# Altium schematic sheet 13_MRAM_RTC_WDT.SchDoc(13_MRAM_RTC_WDT)
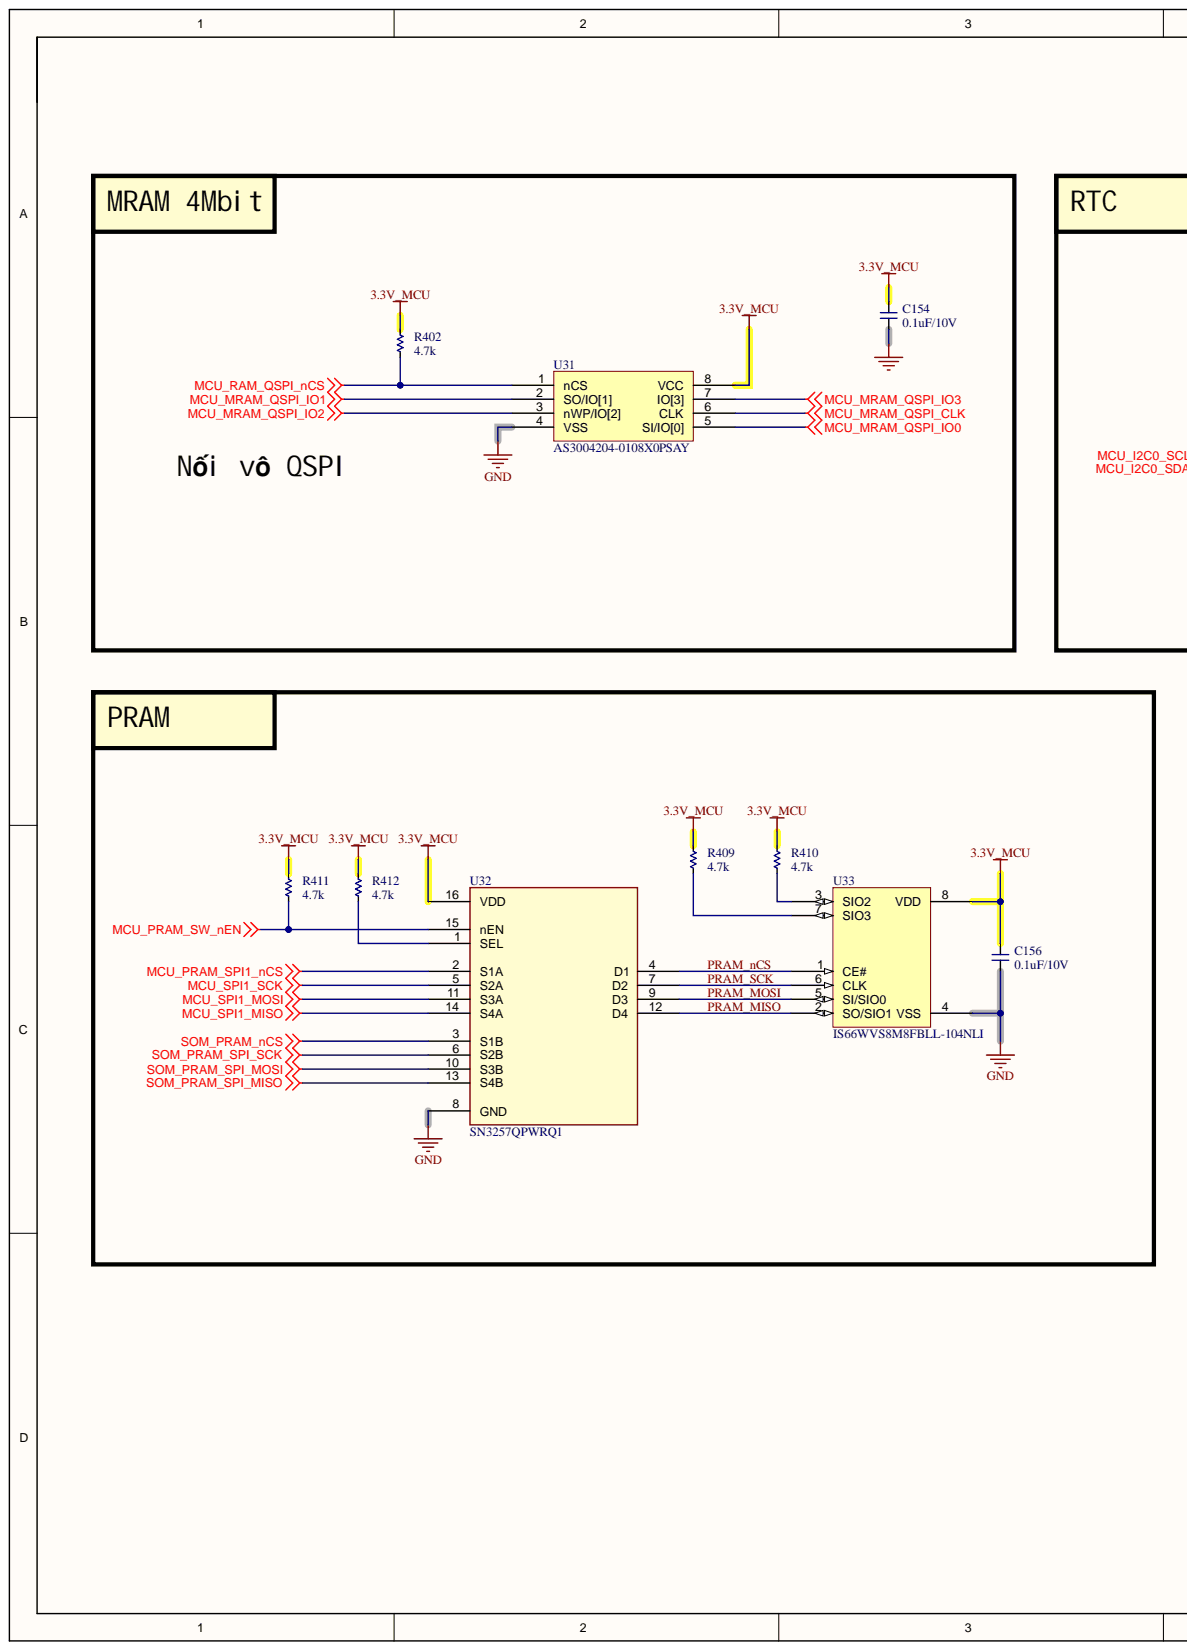
[diagram p0: 13_MRAM_RTC_WDT.SchDoc, left]
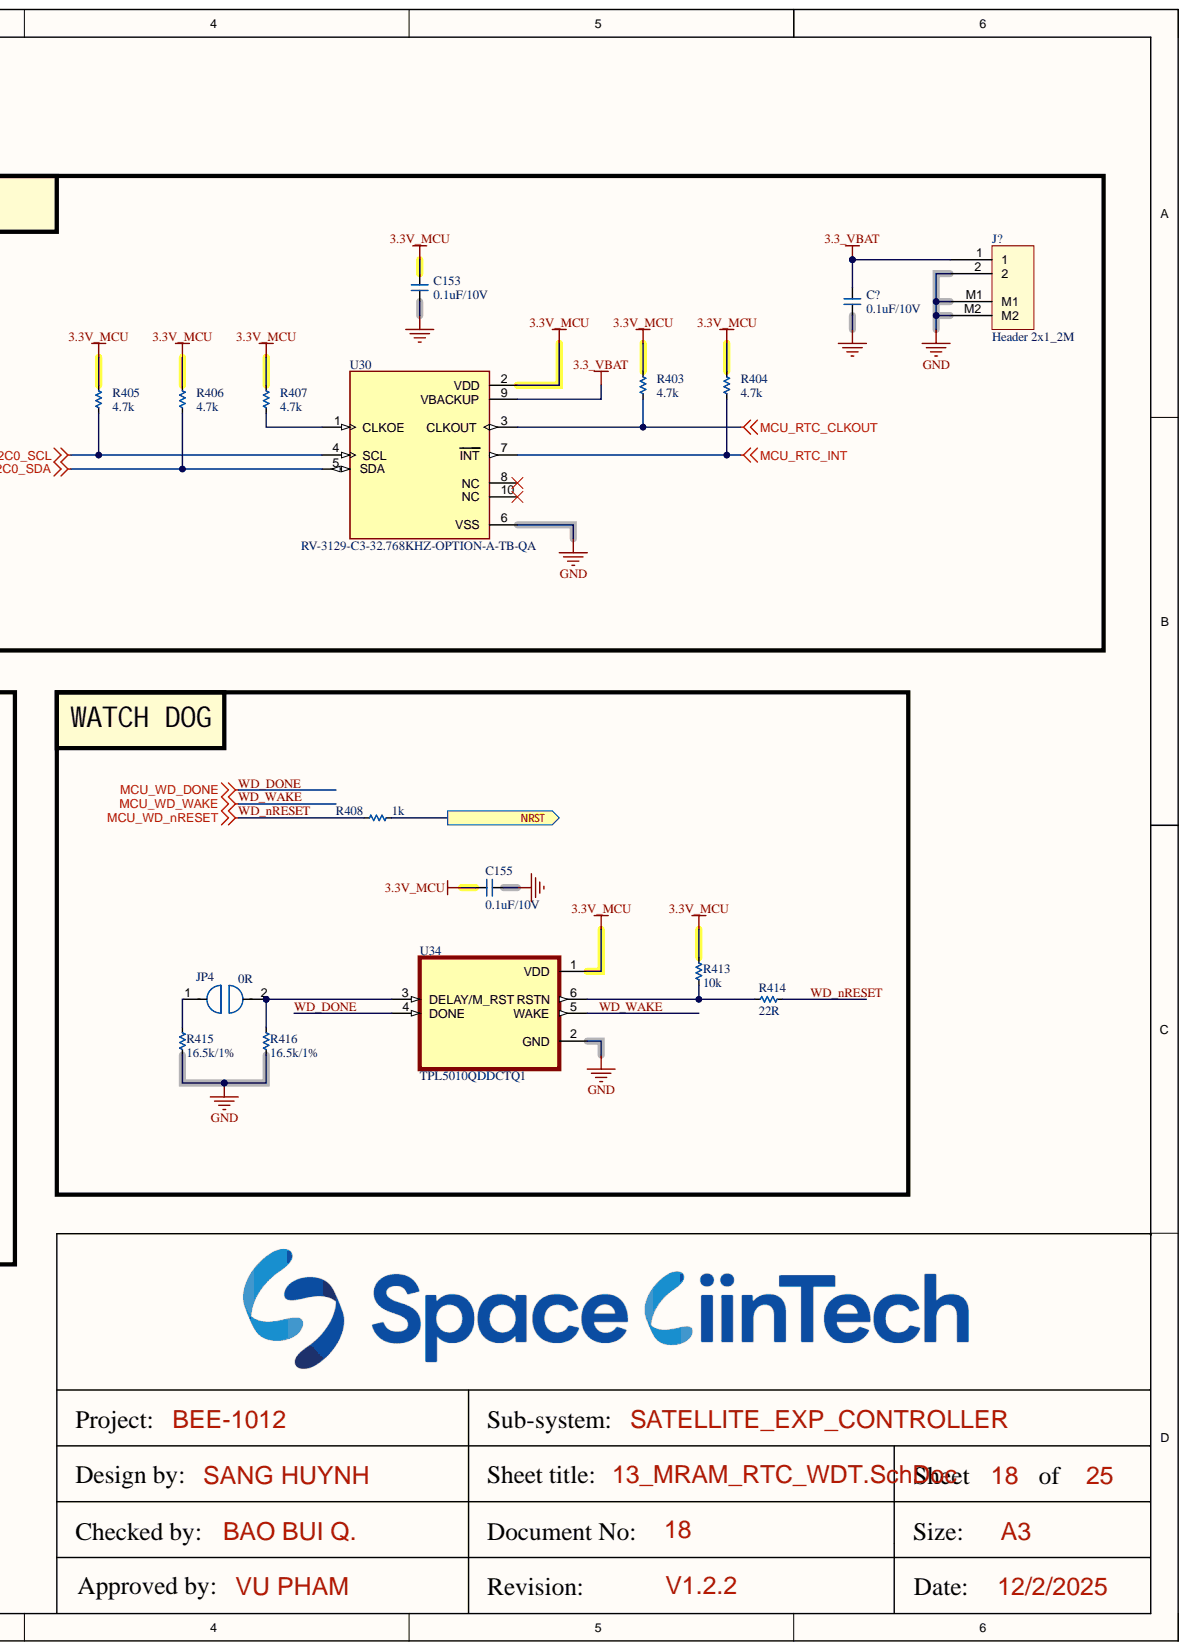
[diagram p1: 13_MRAM_RTC_WDT.SchDoc, right]

## Parts
C153  0.1uF/10V  [CAP_C_1005X60]
C154  0.1uF/10V  [CAP_C_1005X60]
C155  0.1uF/10V  [CAP_C_1005X60]
C156  0.1uF/10V  [CAP_C_1005X60]
C?  0.1uF/10V  [CAP_C_1005X60]
J?  Header 2x1_2M  [MOLEX_0533980271]
JP4  0R  [RES_C_1608X60]
R402  4.7k  [RES_C_1005X40]
R403  4.7k  [RES_C_1005X40]
R404  4.7k  [RES_C_1005X40]
R405  4.7k  [RES_C_1005X40]
R406  4.7k  [RES_C_1005X40]
R407  4.7k  [RES_C_1005X40]
R408  1k  [RES_C_1005X40]
R409  4.7k  [RES_C_1005X40]
R410  4.7k  [RES_C_1005X40]
R411  4.7k  [RES_C_1005X40]
R412  4.7k  [RES_C_1005X40]
R413  10k  [RES_C_1005X40]
R414  22R  [RES_C_1005X40]
R415  16.5k/1%  [RES_C_1005X40]
R416  16.5k/1%  [RES_C_1005X40]
U30  RV-3129-C3-32.768KHZ-OPTION-A-TB-QA  [IC_RV-3129-C3_32.768KHZ_OPTION_A_TB_QA]
U31  AS3004204-0108X0PSAY  [SOIC127P790X216-8]
U32  SN3257QPWRQ1  [SOIC_PRQ1_TEX]
U33  IS66WVS8M8FBLL-104NLI  [SOIC8_150MIL_N_ISI]
U34  TPL5010QDDCTQ1  [SOT95P280X145-6N]

## Ports
NRST

## Nets
3.3_VBAT: C?.2 J?.1 U30.9(VBACKUP)
3.3V_MCU: C153.2 C154.2 C155.2 C156.1 R402.2 R403.2 R404.2 R405.2 R406.2 R407.1 R409.2 R410.2 R411.2 R412.2 R413.2 U30.2(VDD) U31.8(VCC) U32.16(VDD) U33.8(VDD) U34.1(VDD)
GND: C153.1 C154.1 C155.1 C156.2 C?.1 J?.2 J?.M1 J?.M2 R415.1 R416.1 U30.6(VSS) U31.4(VSS) U32.8(GND) U33.4(VSS) U34.2(GND)
MCU_I2C0_SCL: R405.1 U30.4(SCL)
MCU_I2C0_SDA: R406.1 U30.5(SDA)
MCU_MRAM_QSPI_CLK: U31.6(CLK)
MCU_MRAM_QSPI_IO0: U31.5(SI/IO[0])
MCU_MRAM_QSPI_IO1: U31.2(SO/IO[1])
MCU_MRAM_QSPI_IO2: U31.3(nWP/IO[2])
MCU_MRAM_QSPI_IO3: U31.7(IO[3])
MCU_PRAM_SPI1_nCS: U32.2(S1A)
MCU_PRAM_SW_nEN: R411.1 U32.15(nEN)
MCU_RAM_QSPI_nCS: R402.1 U31.1(nCS)
MCU_RTC_CLKOUT: R403.1 U30.3(CLKOUT)
MCU_RTC_INT: R404.1 U30.7(INT)
MCU_SPI1_MISO: U32.14(S4A)
MCU_SPI1_MOSI: U32.11(S3A)
MCU_SPI1_SCK: U32.5(S2A)
SOM_PRAM_nCS: U32.3(S1B)
SOM_PRAM_SPI_MISO: U32.13(S4B)
SOM_PRAM_SPI_MOSI: U32.10(S3B)
SOM_PRAM_SPI_SCK: U32.6(S2B)
NRST: R408.1 ; port NRST
PRAM_MISO: U32.12(D4) U33.2(SO/SIO1)
PRAM_MOSI: U32.9(D3) U33.5(SI/SIO0)
PRAM_nCS: U32.4(D1) U33.1(CE#)
PRAM_SCK: U32.7(D2) U33.6(CLK)
WD_DONE: U34.4(DONE)
WD_nRESET: R408.2 R414.1
WD_WAKE: U34.5(WAKE)
NetJP4_1: JP4.1 R415.2
NetJP4_2: JP4.2 R416.2 U34.3(DELAY/M_RST)
NetR407_2: R407.2 U30.1(CLKOE)
NetR409_1: R409.1 U33.7(SIO3)
NetR410_1: R410.1 U33.3(SIO2)
NetR412_1: R412.1 U32.1(SEL)
NetR413_1: R413.1 R414.2 U34.6(RSTN)

Not connected: U30 8(NC) 10(NC)

## Library data of the parts
C153-C156 C? = _CAP_C_2: CAP CHIP; Component Kind: Standard; Library Name: LABLIB.SchLib
JP4 = JUMPER: Component Kind: Standard; Library Name: EXP_V1.SchLib
R402-R416 = _RES_B_2: RES; Component Kind: Standard; Library Name: LABLIB.SchLib
U30 = RV-3129-C3_32.768KHZ_OPTION_A_TB_QA: Real-Time Clock Module, Temperature compensated, in 2.5 x 3.7 mm package; MF: Micro Crystal; MP: RV-3129-C3 32.768KHZ OPTION A TB QA; Check_prices: https://www.snapeda.com/parts/RV-3129-C3%2032.768KHZ%20OPTION%20A%20TB%20QA/Micro+Crystal/view-part/?ref=eda; Component Kind: Standard; Library Name: RV-3129-C3_32.768KHZ_OPTION_A_TB_QA.IntLib; Package: QFN-10 Micro Crystal; SnapEDA_Link: https://www.snapeda.com/parts/RV-3129-C3%2032.768KHZ%20OPTION%20A%20TB%20QA/Micro+Crystal/view-part/?ref=snap
U31 = IC_RAM_AS3004204-0108X0PSAY: Component Kind: Standard; Library Name: LABLIB.SchLib
U32 = IC_SW_SPI_SN3257QPWRQ1: Automotive 5-V, 2:1 (SPDT), 4-channel switch with 1.8-V logic and powered-off protection 16-TSSOP -40 to 125; Manufacturer_Name: Texas Instruments; Component Kind: Standard; Datasheet: https://www.ti.com/lit/gpn/sn3257-q1; Manufacturer_Part_Number: SN3257QPWRQ1; RefDes: RefDes; Type: Type; Copyright: Copyright (C) 2025 Ultra Librarian. All rights reserved.; Library Name: LABLIB.SchLib
U33 = IS66WVS4M8BLL-104NLI: No Description Available; Manufacturer_Name: ISSI; Component Kind: Standard; Manufacturer_Part_Number: IS66WVS4M8BLL-104NLI; RefDes: RefDes; Type: Memory; Copyright: Copyright (C) 2025 Ultra Librarian. All rights reserved.; Library Name: LABLIB.SchLib
J? = Header 2x1_2M: Component Kind: Standard; Library Name: LABLIB.SchLib
U34 = TPL5010QDDCTQ1: Availability: Unavailable; Component Kind: Standard; Library Name: TPL5010QDDCTQ1.IntLib; MP: TPL5010QDDCTQ1; Package: None; Price: None
